# Altium schematic sheet tsac-distribution.SchDoc(tsac-distribution)
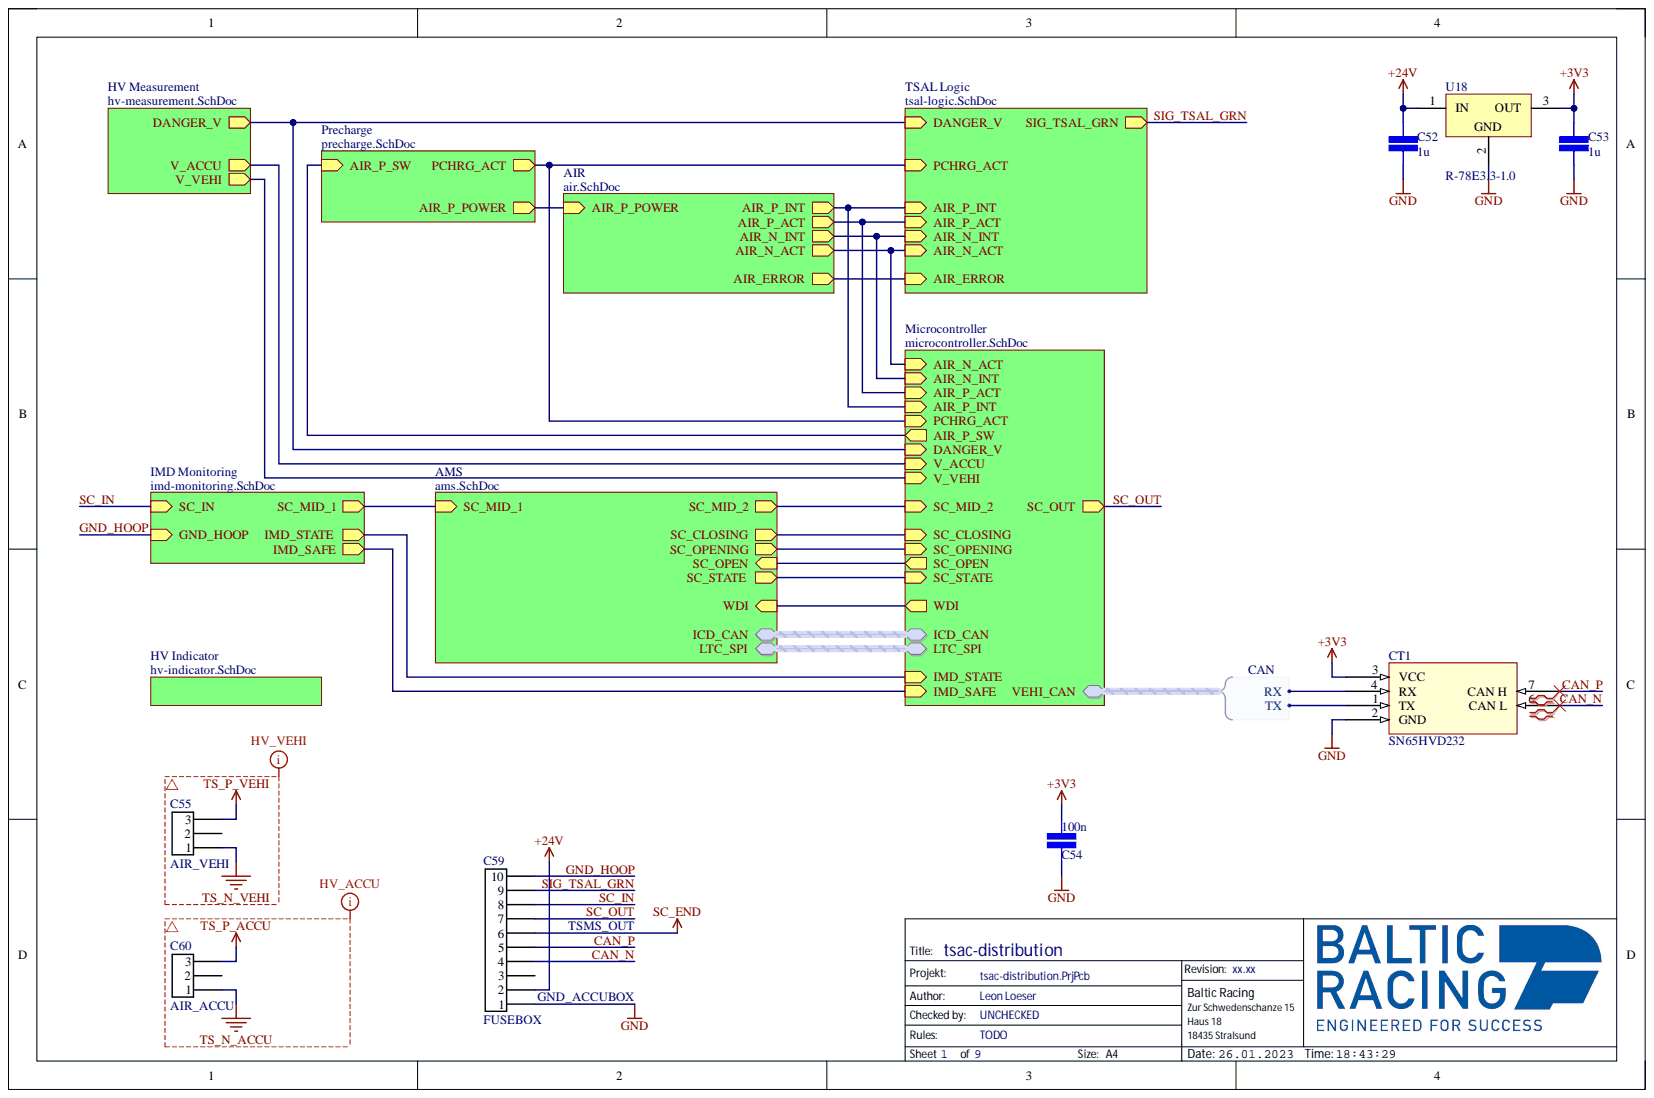
[diagram p0: tsac-distribution.SchDoc]

## Parts
C52  value 1u  [CAP 0805/2012]  (hidden comment: SMD Capacitor)
C53  value 1u  [CAP 0805/2012]  (hidden comment: SMD Capacitor)
C54  value 100n  [CAP 0603/1608]  (hidden comment: SMD Capacitor)
C55  AIR_VEHI  [43650-0315]
C59  FUSEBOX  [43045-1012]
C60  AIR_ACCU  [43650-0315]
CT1  SN65HVD232  [SOIC8 CAN-Transceiver]
U18  R-78E3.3-1.0  [SIP3_RECOM]

## Nets
+3V3: C53.1 C54.1 CT1.3(VCC) U18.3
+24V: C52.1 C59.2 U18.1
GND: C52.2 C53.2 C54.2 C59.1 CT1.2(GND) U18.2
SC_END: C59.6
TS_N_ACCU: C60.1
TS_N_VEHI: C55.1
TS_P_ACCU: C60.3
TS_P_VEHI: C55.3
CAN_N: C59.4 CT1.6(CAN_L)
CAN_P: C59.5 CT1.7(CAN_H)
GND_HOOP: C59.10
SC_IN: C59.8
SC_OUT: C59.7
SIG_TSAL_GRN: C59.9
NetC55_2: C55.2
NetC59_3: C59.3
NetC60_2: C60.2
NetCT1_1: CT1.1(TX)
NetCT1_4: CT1.4(RX)
AIR_ERROR:
AIR_N_ACT:
AIR_N_INT:
AIR_P_ACT:
AIR_P_INT:
AIR_P_POWER:
AIR_P_SW:
DANGER_V:
ICD_CAN.RX:
ICD_CAN.TX:
IMD_SAFE:
IMD_STATE:
LTC_SPI.CS:
LTC_SPI.MISO:
LTC_SPI.MOSI:
LTC_SPI.SCK:
PCHRG_ACT:
SC_CLOSING:
SC_MID_1:
SC_MID_2:
SC_OPEN:
SC_OPENING:
SC_STATE:
V_ACCU:
V_VEHI:
WDI:

## Library data of the parts
C52 = SMD Capacitor: Capacitor with multiple Footprints; Voltage: 25V; Component Kind: Standard; Library Name: Standardbauteile.SchLib
C53 C54 = SMD Capacitor: Capacitor with multiple Footprints; Voltage: 6.3V; Component Kind: Standard; Library Name: Standardbauteile.SchLib
C55 C60 = MicroFit3.0 3-Pin: Molex MicroFit3.0 3-Pin; Component Kind: Standard; Library Name: Standardbauteile.IntLib
C59 = MicroFit3.0 10-Pin: Micro-Fit 3.0 10-Pin  600V  8.5V; Component Kind: Standard; Library Name: Standardbauteile.IntLib
CT1 = SN65HVD232: Standard CAN-Transciever 3.3V; Component Kind: Standard; Library Name: Standardbauteile.IntLib
U18 = R-78E3.3-1.0: Nonisolated 3.3V Switchmode converter; Component Kind: Standard; Library Name: Standardbauteile.IntLib
